# Altium schematic sheet FPGAMisc.SchDoc(FPGAMisc)
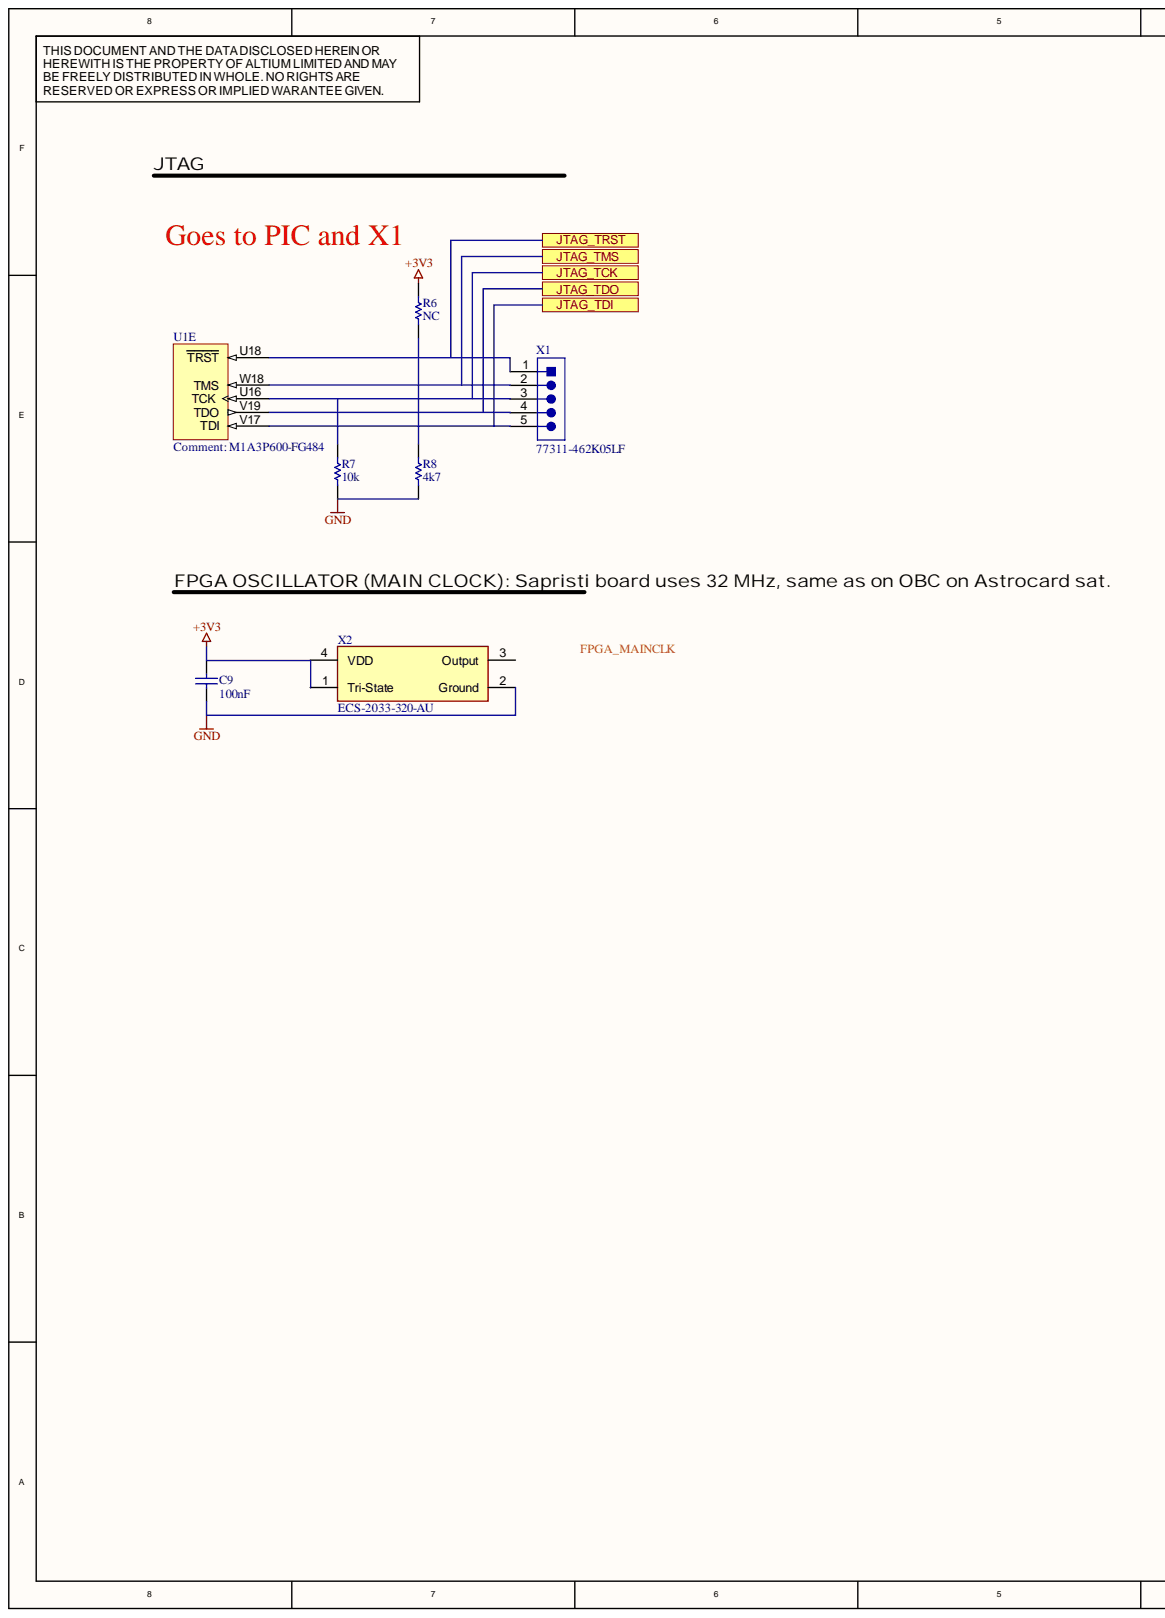
[diagram p0: FPGAMisc.SchDoc, left]
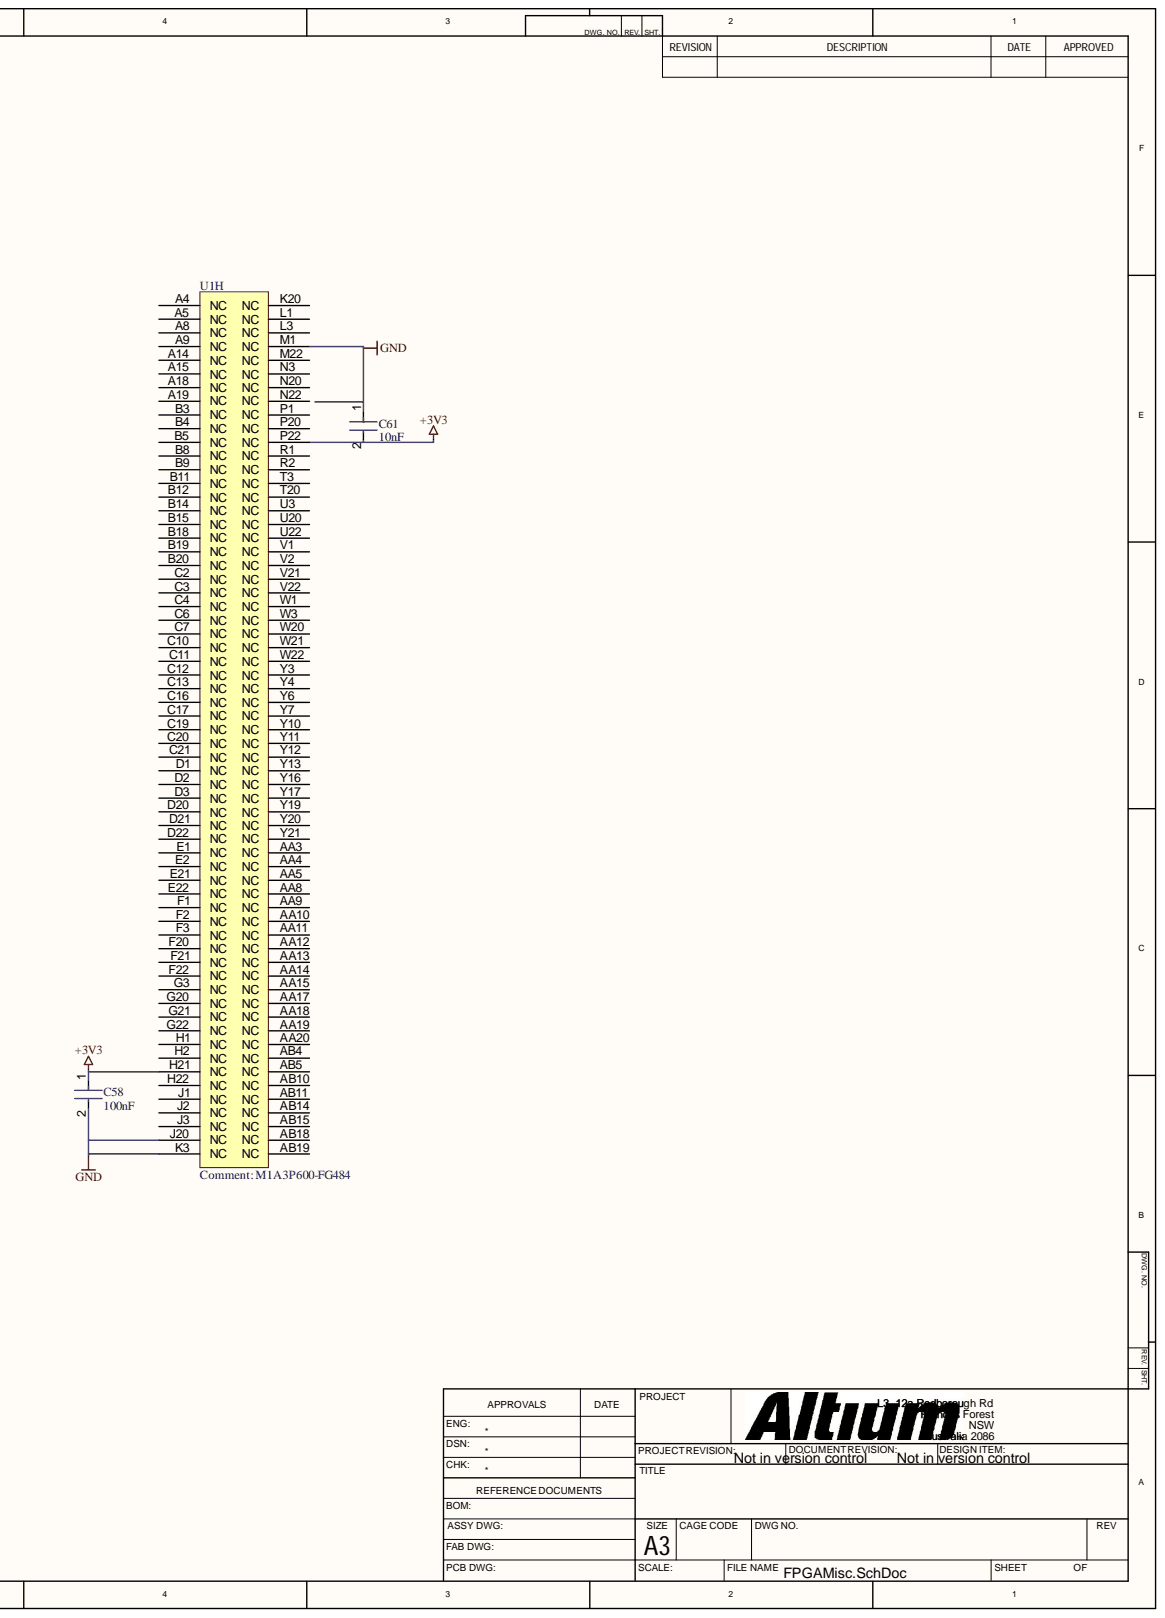
[diagram p1: FPGAMisc.SchDoc, right]

## Parts
C9  100nF  [CAPC1005X60X30ML05T10]
C58  100nF  [FP-GRM155-0_05-IPC_C]
C61  10nF  [FP-GRM155-0_05-IPC_C]
R6  NC  [RESC1005X40X25LL05T10]
R7  10k  [RESC1005X40X25LL05T10]
R8  4k7  [RESC1005X40X25LL05T10]
U1  M1A3P600-FG484  [FG484]  (parts E,H)
X1  77311-462K05LF  [FCI-77311-5_V]
X2  ECS-2033-320-AU  [FP-ECS-2033-MFG]

## Ports
JTAG_TCK, JTAG_TDI, JTAG_TDO, JTAG_TMS, JTAG_TRST

## Nets
+3V3: C9.2 C58.1 C61.2 R6.2 U1.H21(NC) U1.P22(NC)
GND: C9.1 C58.2 C61.1 R7.1 R8.1 U1.J20(NC) U1.K3(NC) U1.M1(NC) X2.2(Ground)
JTAG_TCK: R7.2 U1.U16(TCK) X1.3 ; port JTAG_TCK
JTAG_TDI: R6.1 R8.2 U1.V17(TDI) X1.5 ; port JTAG_TDI
JTAG_TDO: U1.V19(TDO) X1.4 ; port JTAG_TDO
JTAG_TMS: U1.W18(TMS) X1.2 ; port JTAG_TMS
JTAG_TRST: U1.U18(TRST) X1.1 ; port JTAG_TRST
FPGA_MAINCLK:

Not connected: U1 A4(NC) A5(NC) A8(NC) A9(NC) A14(NC) A15(NC) A18(NC) A19(NC) AA3(NC) AA4(NC) AA5(NC) AA8(NC) AA9(NC) AA10(NC) AA11(NC) AA12(NC) AA13(NC) AA14(NC) AA15(NC) AA17(NC) AA18(NC) AA19(NC) AA20(NC) AB4(NC) AB5(NC) AB10(NC) AB11(NC) AB14(NC) AB15(NC) AB18(NC) AB19(NC) B3(NC) B4(NC) B5(NC) B8(NC) B9(NC) B11(NC) B12(NC) B14(NC) B15(NC) B18(NC) B19(NC) B20(NC) C2(NC) C3(NC) C4(NC) C6(NC) C7(NC) C10(NC) C11(NC) C12(NC) C13(NC) C16(NC) C17(NC) C19(NC) C20(NC) C21(NC) D1(NC) D2(NC) D3(NC) D20(NC) D21(NC) D22(NC) E1(NC) E2(NC) E21(NC) E22(NC) F1(NC) F2(NC) F3(NC) F20(NC) F21(NC) F22(NC) G3(NC) G20(NC) G21(NC) G22(NC) H1(NC) H2(NC) H22(NC) J1(NC) J2(NC) J3(NC) K20(NC) L1(NC) L3(NC) M22(NC) N3(NC) N20(NC) N22(NC) P1(NC) P20(NC) R1(NC) R2(NC) T3(NC) T20(NC) U3(NC) U20(NC) U22(NC) V1(NC) V2(NC) V21(NC) V22(NC) W1(NC) W3(NC) W20(NC) W21(NC) W22(NC) Y3(NC) Y4(NC) Y6(NC) Y7(NC) Y10(NC) Y11(NC) Y12(NC) Y13(NC) Y16(NC) Y17(NC) Y19(NC) Y20(NC) Y21(NC); X2 1(Tri-State) 3(Output) 4(VDD)

## Library data of the parts
C9 = CMP-2008-02046-1: Manufacturer: Kemet; Part Number: C0402C104K8PACTU; Component Kind: Standard; Library Name: Altium Content Vault
C58 = CMP-2008-04637-5: Chip Multilayer Ceramic Capacitors for General Purpose, 0402, 10000pF, X7R, 15%, 10%, 50V GRM155R71H103KA88D; Capacitance: 10nF; Voltage: 25V; Case Code (Imperial): 0402; Case Code (Metric): 1005; Case/Package: 0402; Component Kind: Standard; Depth: 0.5mm; Dielectric: X7R; Height: 0.5mm; Lead Free: Lead Free; Length: 1mm; Library Name: Altium Content Vault; Material: Ceramic; Max Operating Temperature: 125°C; Min Operating Temperature: -55°C; Mount: Surface Mount; Number of Pins: 2; Package Quantity: 1; Packaging: Tape and Reel; Radiation Hardening: No; REACH SVHC: No SVHC; Termination: SMD/SMT; Thickness: 0.022inch; Tolerance: 10%; Voltage Rating: 50V; Voltage Rating (DC): 50V; Width: 0.02inch
C61 = CMP-2008-04637-5: Chip Multilayer Ceramic Capacitors for General Purpose, 0402, 10000pF, X7R, 15%, 10%, 50V GRM155R71H103KA88D; Voltage: 25V; Capacitance: 10nF; Case Code (Imperial): 0402; Case Code (Metric): 1005; Case/Package: 0402; Component Kind: Standard; Depth: 0.5mm; Dielectric: X7R; Height: 0.5mm; Lead Free: Lead Free; Length: 1mm; Library Name: Altium Content Vault; Material: Ceramic; Max Operating Temperature: 125°C; Min Operating Temperature: -55°C; Mount: Surface Mount; Number of Pins: 2; Package Quantity: 1; Packaging: Tape and Reel; Radiation Hardening: No; REACH SVHC: No SVHC; Termination: SMD/SMT; Thickness: 0.022inch; Tolerance: 10%; Voltage Rating: 50V; Voltage Rating (DC): 50V; Width: 0.02inch
R6-R8 = CMP-2002-07353-1: Manufacturer: Yageo / Phycomp; Part Number: RC0402FR-0710KL; Component Kind: Standard; Library Name: Altium Content Vault
U1 = CMP-0097-00007-1: M1-Ready ProASIC3 Flash Family FPGA, 235 User IOs, 600K System Gates, 108 Kbits RAM, 1 Kbit Flash ROM, 1 PLL, 484-Ball FBGA, Commercial Grade; Code_IPC: BGA100P22X22-484; Code_JEDEC: MS-034-AAJ-1; Component Kind: Standard; DatasheetVersion: v1.3, Oct-2009; Library Name: Altium Content Vault; PackageDescription: 484-Ball Fine Pitch Plastic Ball Grid Array (FG484) - Fully Populated; PackageReference: FG484; PackageVersion: ver11.2, Nov-2009
X1 = CMP-1698-00006-1: Male Header, Pitch 2.54 mm, 1 x 5 Position, Height 16.24 mm, Tail Length 3.05 mm,   -65 to 125 degC, RoHS, Tape and Reel; Current Rating: 1 A; Gender: Female; Shielding: Shielded; Component Kind: Standard; ComponentLink1Description: Manufacturer URL; ComponentLink1URL: http://portal.fciconnect.com/; ComponentLink2Description: Datasheet; ComponentLink2URL: http://portal.fciconnect.com/Comergent//fci/drawing/77311.pdf; Contact Material: Brass; DatasheetVersion: Rev. CK, 07/2016; Library Name: Altium Content Vault; Max Operating Temperature: 85degC; Min Operating Temperature: -25degC; Package Quantity: 500; PackageDescription: Male Header, Pitch 2.54 mm, 1 x 5 Position, Height 16.24 mm, Tail Length 3.05 mm; PackageReference: 77311-5; Packaging: Tape and Reel; RoHSCompliant: True
X2 = CMP-40224-000021-1: XTAL OSC XO 25.0000MHZ CMOS SMD; Frequency: 25MHz; Frequency Stability: 50ppm; Max Supply Current: 13mA; Case/Package: SMD/SMT; Component Kind: Standard; Height: 0.035inch; Lead Free: Lead Free; Length: 0.098inch; Library Name: Altium Content Vault; Max Operating Temperature: 85°C; Min Operating Temperature: -40°C; Mount: Surface Mount; Package Quantity: 1; Packaging: Tape and Reel; Width: 0.079inch
